# Altium schematic sheet MIcrocontroller Schematic.SchDoc("MIcrocontroller Schematic")
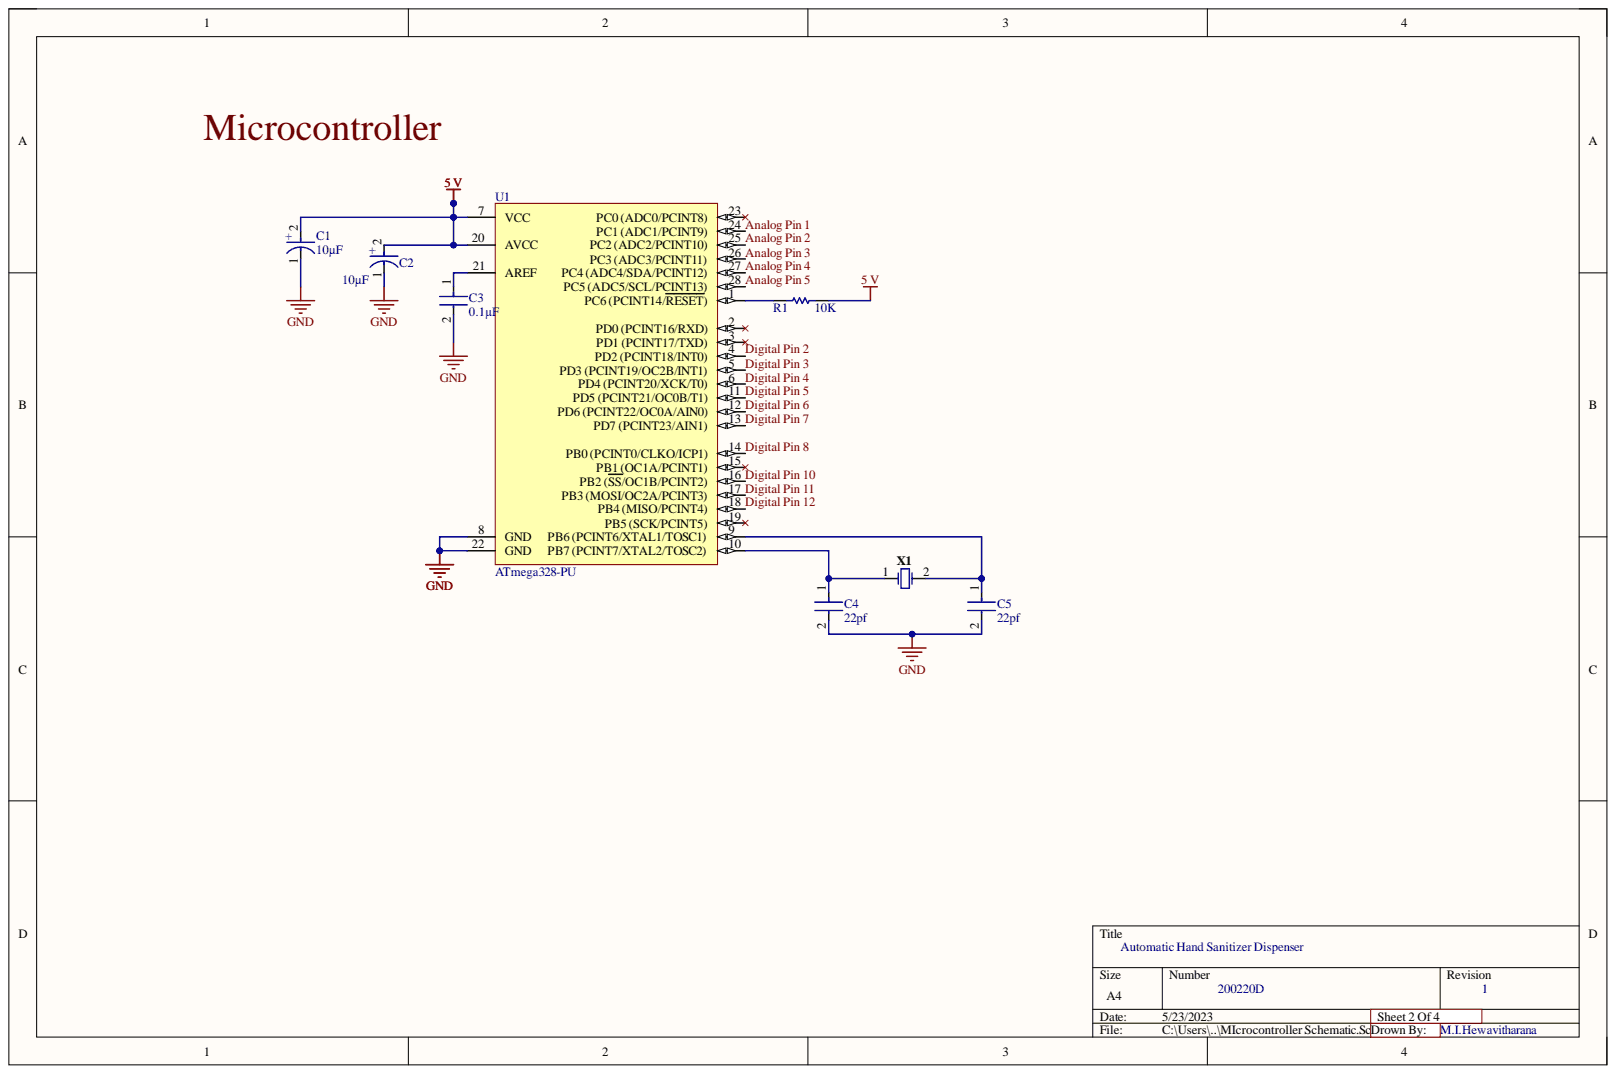
[diagram p0: MIcrocontroller Schematic.SchDoc]

## Parts
C1  10µF  [FP-RAD-TH-D_16_0_5-L_25_2-MFG]
C2  10µF  [FP-RAD-TH-D_16_0_5-L_25_2-MFG]
C3  0.1µF  [FP-VY2472M49Y5UG63V7-MFG]
C4  22pf  [FP-VY2472M49Y5UG63V7-MFG]
C5  22pf  [FP-VY2472M49Y5UG63V7-MFG]
R1  10K  [RESA60-630X240]
U1  ATmega328-PU  [28P3]
X1  FOXSLF/160-20  [FOX-FOXSLF160-20]  (value not drawn)

## Nets
5 V: C1.2 C2.2 R1.2 U1.7(VCC) U1.20(AVCC)
GND: C1.1 C2.1 C3.2 C4.2 C5.2 U1.8(GND) U1.22(GND)
Analog Pin 1: U1.24(PC1_(ADC1/PCINT9))
Analog Pin 2: U1.25
Analog Pin 3: U1.26(PC3_(ADC3/PCINT11))
Analog Pin 4: U1.27
Analog Pin 5: U1.28(PC5_(ADC5/SCL/PCINT13))
Digital Pin 2: U1.4(PD2_(PCINT18/INT0))
Digital Pin 3: U1.5(PD3_(PCINT19/OC2B/INT1))
Digital Pin 4: U1.6(PD4_(PCINT20/XCK/T0))
Digital Pin 5: U1.11(PD5_(PCINT21/OC0B/T1))
Digital Pin 6: U1.12(PD6_(PCINT22/OC0A/AIN0))
Digital Pin 7: U1.13(PD7_(PCINT23/AIN1))
Digital Pin 8: U1.14(PB0_(PCINT0/CLKO/ICP1))
Digital Pin 10: U1.16(PB2_(SS/OC1B/PCINT2))
Digital Pin 11: U1.17(PB3_(MOSI/OC2A/PCINT3))
Digital Pin 12: U1.18(PB4_(MISO/PCINT4))
NetC3_1: C3.1 U1.21(AREF)
NetC4_1: C4.1 U1.10 X1.1
NetC5_1: C5.1 U1.9 X1.2
NetR1_1: R1.1 U1.1(PC6_(PCINT14/RESET))

Not connected: U1 2(PD0_(PCINT16/RXD)) 3(PD1_(PCINT17/TXD)) 15(PB1_(OC1A/PCINT1)) 19(PB5_(SCK/PCINT5)) 23(PC0_(ADC0/PCINT8))

## Library data of the parts
C1 C2 = CMP-05427-001116-1: Aluminum Electrolytic Capacitors (Radial Lead Type) 47uF ±20% 400V; Dielectric Material: Aluminium; Dissipation Factor: 0.24%; Lead Diameter: 0.8mm; Leakage Current: 1.138mA; Life (Hours): 10000Hour; Ripple Current: 1.18A; Capacitance: 47uF; Case/Package: Radial; Component Kind: Standard; Construction: RADIAL; Diameter: 16mm; Height: 25mm; Height - Seated (Max): 0.984inch; Lead Free: Lead Free; Lead Pitch: 7.5mm; Length: 25mm; Library Name: Altium Content Vault; Max Operating Temperature: 105°C; Min Operating Temperature: -25°C; Mount: Through Hole; Number of Pins: 2; Package Quantity: 100; Packaging: Bulk; Radiation Hardening: No; REACH SVHC: No SVHC; Ripple Current (AC): 1.18A; RoHS Compliant: Yes; Series: EE; Termination: Radial; Tolerance: 20%; Voltage: 400V; Voltage Rating: 400V; Voltage Rating (DC): 400V
C3-C5 = CMP-02417-000047-1: Capacitor Ceramic 4700pF ±20% Y5U BULK RADIAL; Body Diameter: 12.5mm; Capacitance: 4.7nF; Dissipation Factor: 2.5%; Lead Diameter: 0.6mm; Lead/Base Style: Kinked; Voltage Rating: 300V; Case/Package: Radial; Component Kind: Standard; Diameter: 12.5mm; Dielectric: Y5U; Height - Seated (Max): 0.65inch; Lead Pitch: 7.5mm; Library Name: Altium Content Vault; Max Operating Temperature: 125°C; Min Operating Temperature: -40°C; Mount: Through Hole; Package Quantity: 1000; Packaging: Bulk; REACH SVHC: No SVHC; Resistor Type: Ceramic; RoHS Compliant: Yes; Series: VY2; Termination: Radial; Thickness: 5mm; Tolerance: 20%; Voltage Rating (AC): 300V; Voltage Rating (DC): 300V; Weight: 0.040932oz
R1 = CMP-1659-00075-1: Axial Resistor, 1 KOhm, +/- 1%, 250 mW, -55 to 155 degC, 2-Pin THD, RoHS, Bulk; Power Rating: 250 mW; Resistance: 10 kohm; Voltage Rating: 250 V; Case\Package: Axial; Component Kind: Standard; ComponentLink1Description: Manufacturer URL; ComponentLink1URL: http://www.yageo.com/; ComponentLink2Description: Datasheet; ComponentLink2URL: http://www.yageo.com/documents/recent/Yageo%20LR_MFR_2013.pdf; DatasheetVersion: Apr-13; Length: 0.248 inch; Library Name: Altium Content Vault; Max Operating Temperature: 155 degC; Min Operating Temperature: -55 degC; Package Quantity: 200; PackageDescription: 2-Pin Axial Lead, Dia 2.4 mm, Body Length 6.3 mm; PackageReference: MFR-25; Packaging: Bulk; RoHSCompliant: true; Tolerance: 1%
U1 = CMP-0095-00015-2: 8-bit AVR Microcontroller, 32KB Flash, 1KB EEPROM, 2KB SRAM, 28-pin PDIP, Industrial Grade (-40°C to 85°C); Component Kind: Standard; ComponentLink1Description: Manufacturer URL; ComponentLink1URL: http://www.atmel.com/; ComponentLink2Description: ATmega48A/48PA/88A/88PA/168A/168PA/328/328P Datasheet; ComponentLink2URL: http://www.atmel.com/dyn/resources/prod_documents/8271.pdf; ComponentLink3Description: ATmega48A/48PA/88A/88PA/168A/168PA/328/328P Summary; ComponentLink3URL: http://www.atmel.com/dyn/resources/prod_documents/8271S.pdf; DatasheetVersion: revD, May-2011; Library Name: Altium Content Vault; PackageDescription: 28-lead (0.300"/7.62mm Wide) Plastic Dual Inline Package (PDIP); PackageReference: 28P3; PackageVersion: revB, Sep-2001; RevisionNote: DatasheetVersion updated. URLs added.
X1 = CMP-1749-00003-1: Resistance Weld Thru-Hole Crystal, 16 MHz, -20 to 70 degC, 2-Pin THD, RoHS, Bulk; Case\Package: Axial; Power Rating: 250 mW; Resistance: 221 kohm; Tolerance: 1%; Voltage Rating: 250 V; Component Kind: Standard; ComponentLink1Description: Manufacturer URL; ComponentLink1URL: http://www.foxonline.com/; ComponentLink2Description: Datasheet; ComponentLink2URL: http://www.foxonline.com/pdfs/hc49slf.pdf; DatasheetVersion: Mar-15; Length: 0.248 inch; Library Name: Altium Content Vault; Max Operating Temperature: 155 degC; Min Operating Temperature: -55 degC; Mount: Through Hole; Package Quantity: 200; PackageDescription: 2-Pin Through Hole Device, Body 11.35 x 4.65 mm; PackageReference: FOXSLF160-20; Packaging: Bulk; RoHSCompliant: true
